# Altium schematic sheet Sheet 2 - Moteurs.SchDoc
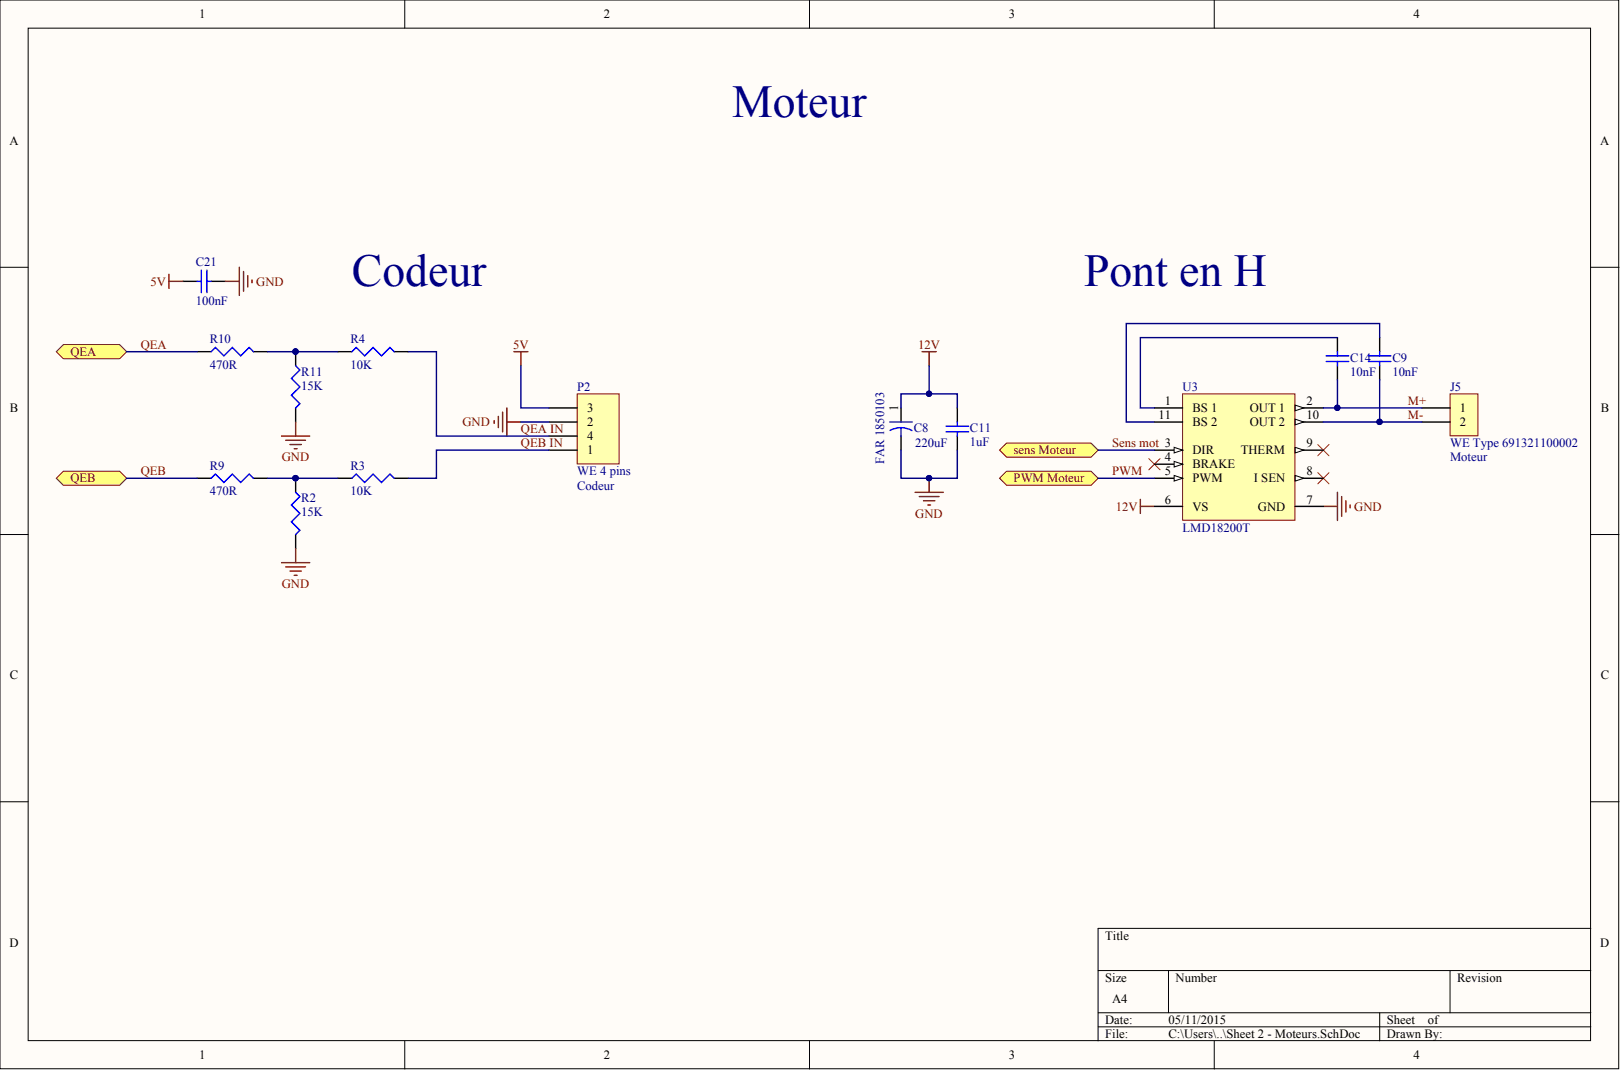
[diagram p0: Sheet 2 - Moteurs.SchDoc]

## Parts
C8  220uF  réference commande: FAR 1850103  [Capa CMS D6.3_E1.8]
C9  10nF  [C0805]
C11  1uF  [C0805]
C14  10nF  [C0805]
C21  100nF  [C0805]
J5  WE Type 691321100002  value 691321100002  value1: Moteur  [691321100002]
P2  WE 4 pins  value1: Codeur  [690367280476]
R2  15K  [0805]
R3  10K  [0805]
R4  10K  [0805]
R9  470R  [0805]
R10  470R  [0805]
R11  15K  [0805]
U3  LMD18200T  [TA11B]

## Ports
PWM MOTEUR, QEA, QEB, SENS MOTEUR

## Nets
5V: C21.2 P2.3
12V: C8.1 C11.2 U3.6(VS)
GND: C8.2 C11.1 C21.1 P2.2 R2.2 R11.2 U3.7(GND)
M+: C14.2 J5.1 U3.2(OUT_1)
M-: C9.2 J5.2 U3.10(OUT_2)
PWM: U3.5(PWM) ; port PWM MOTEUR
QEA: R10.1 ; port QEA
QEA IN: P2.4 R4.2
QEB: R9.1 ; port QEB
QEB IN: P2.1 R3.2
SENS MOT: U3.3(DIR) ; port SENS MOTEUR
NetC9_1: C9.1 U3.11(BS_2)
NetC14_1: C14.1 U3.1(BS_1)
NetR2_1: R2.1 R3.1 R9.2
NetR4_1: R4.1 R10.2 R11.1

Not connected: U3 4(BRAKE) 8(I_SEN) 9(THERM)

## Library data of the parts
C8 = Cap2: EEEFK1C221XP; Component Kind: Standard; Library Name: Miscellaneous Devices.IntLib; PackageReference: CAPR5-4X5; Published: 23-Sep-2002; Signal Integrity: Cap; Simulation: CAP; Supplier 1: Farnell; Supplier Part Number 1: 185-0103; Value: 220uF; Publisher: Altium Limited
C9 C11 C14 C21 = Cap: Capacitor 0805; Component Kind: Standard; LatestRevisionDate: 17-Jul-2002; LatestRevisionNote: Re-released for DXP Platform.; Library Name: Miscellaneous Devices.IntLib; PackageReference: RAD-0.3; Published: 8-Jun-2000; Signal Integrity: Cap; Simulation: CAP; Publisher: Altium Limited
  C9 C14: Value: 10nF
  C11: Value: 1uF
  C21: value: 100nF
J5 = WE-Terminal Blocks Plugable Type 691321100002: WR-TBL Terminal block - serie 3211 - 3.50 mm; Manufacturer: W¨¹rth Elektronik eiSos GmbH; ComponentLink1 Description: Manufacturer; ComponentLink2 Description: Datasheet; NoPins: 2; Component Kind: Standard; ComponentLink1URL: http://www.we-online.com; ComponentLink2URL: http://katalog.we-online.de/em/datasheet/6913211000xx.pdf; Created Date: 9 December 2013; Library Name: 6913211000xx.IntLib; Supplier 1: Farnell; Supplier Part Number 1: 3704816; Created by: Karrer Zheng
P2 = MHDR1X4: Header, 4-Pin; value: 690367280476; Component Kind: Standard; LatestRevisionDate: 17-Jul-2002; LatestRevisionNote: Re-released for DXP Platform.; Library Name: Miscellaneous Connectors.IntLib; Signal Integrity: Connector; Supplier 1: Farnell; Supplier Part Number 1: 378-4710; Publisher: Altium Limited
R2 R3 R9 = Res3: Resistor 0805; Value: 1K; Component Kind: Standard; LatestRevisionDate: 29-May-2009; LatestRevisionNote: IPC-7351 Footprint Added.; Library Name: Miscellaneous Devices.IntLib; PackageDescription: Chip Resistor; PackageReference: J1-0603; Published: 8-Jun-2000; Signal Integrity: Res; Simulation: RESISTOR; Publisher: Altium Limited
R4 R10 R11 = Res3: Resistor 0805; Component Kind: Standard; LatestRevisionDate: 29-May-2009; LatestRevisionNote: IPC-7351 Footprint Added.; Library Name: Miscellaneous Devices.IntLib; PackageDescription: Chip Resistor; PackageReference: J1-0603; Published: 8-Jun-2000; Signal Integrity: Res; Simulation: RESISTOR; Publisher: Altium Limited
  R4: Value: 10K
  R10: Value: 470R
  R11: Value: 15k
U3 = LMD18200T: 3A, 55V H-Bridge; Manufacturer: National Semiconductor; CompType: MiscPower; PackageTopMarking: NSUZXYTTE#*LMD18200T*P+; PowerWise: N; Component Kind: Standard; ComponentLink1Description: LMD18200 Datasheet; ComponentLink1URL: http://www.national.com/ds/LM/LMD18200.pdf; ComponentLink2Description: LMD18200 Web Page; ComponentLink2URL: http://www.national.com/pf/LM/LMD18200.html; ComponentLink3Description: TA11B Package Page; ComponentLink3URL: http://www.national.com/packaging/folders/ta11b.html; DatasheetVersion: Apr-2005; DigiKeyPartNumber: LMD18200T-ND; FirstPublished: 4-Dec-2008; Library Name: NSC MiscPower.IntLib; PackageDescription: TO-220, Molded, .788 x .421in Body, 11 Lead, .067in Pitch; PackageReference: TA11B; PackageVersion: revA, Mar-1987; PinCount: 11; RoHS: O; Supplier 1: Farnell; Supplier Part Number 1: 948-8030; Value: LMD18200T; Publisher: Altium Limited
